# Altium schematic sheet Sheet2.SchDoc(Sheet2)
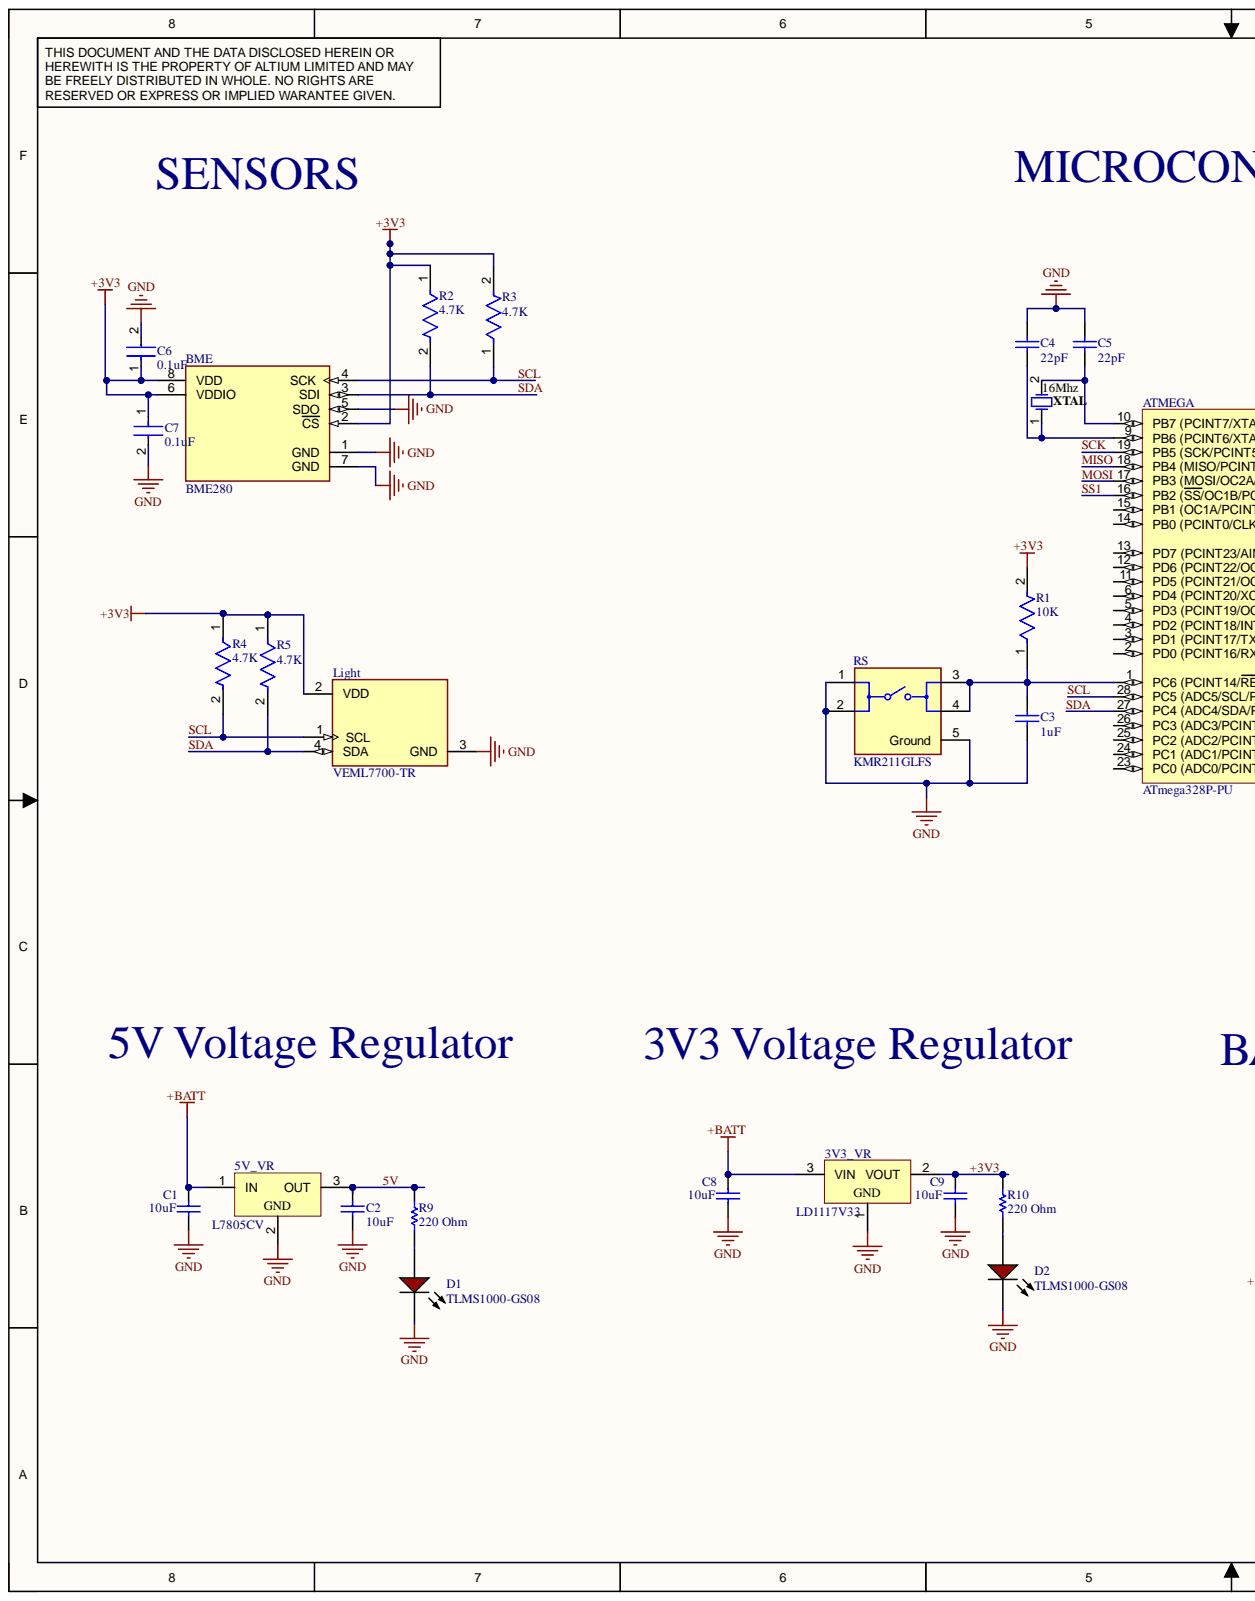
[diagram p0: Sheet2.SchDoc, left]
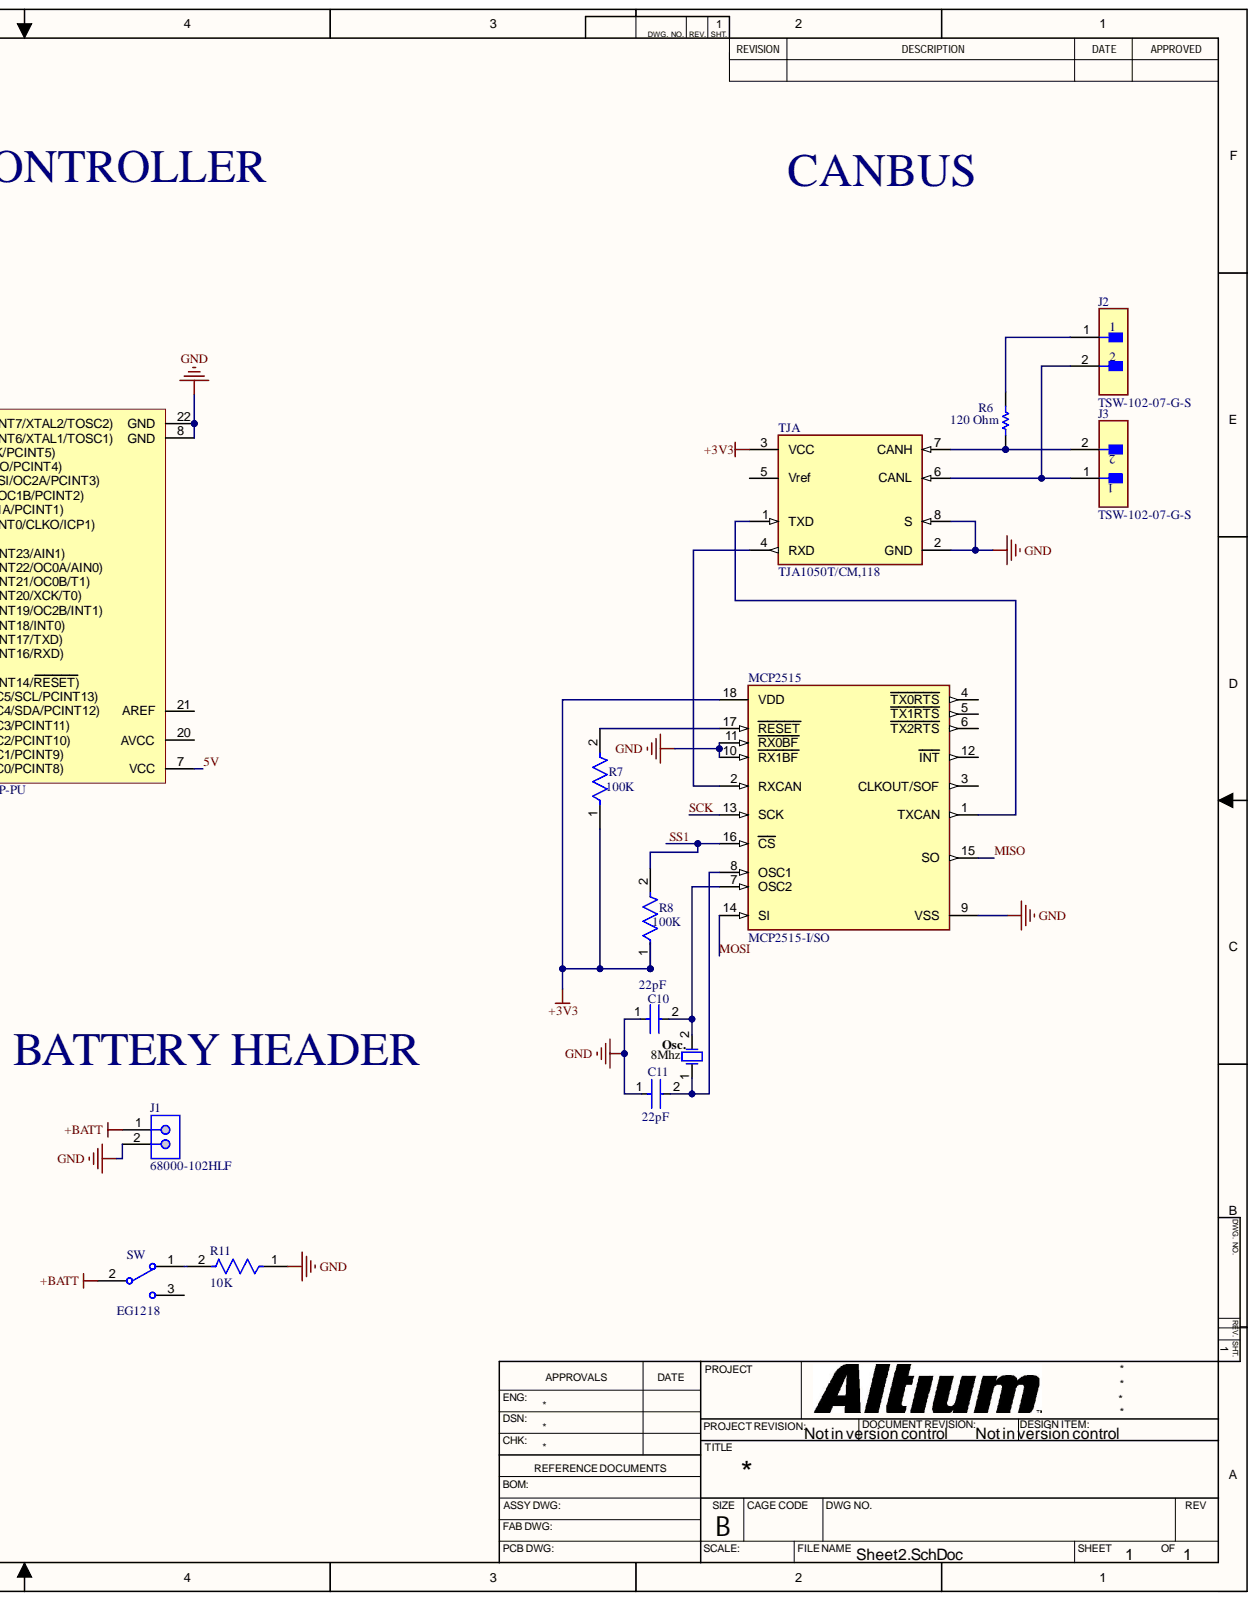
[diagram p1: Sheet2.SchDoc, right]

## Parts
3V3_VR  LD1117V33  [TO220]
5V_VR  L7805CV  [TO220]
ATMEGA  ATmega328P-PU  [28P3]
BME  BME280  [FP-BME280-MFG]
C1  10uF  [CAPC2013X135X45NL10T25]
C2  10uF  [CAPC2013X135X45NL10T25]
C3  1uF  [CAPC1608X90X35ML10T25]
C4  22pF  [CAPC1508X91X25NL25T13]
C5  22pF  [CAPC1508X91X25NL25T13]
C6  0.1uF  [FP-C0805C-DN-MFG]
C7  0.1uF  [FP-C0805C-DN-MFG]
C8  10uF  [CAPC2013X135X45NL10T25]
C9  10uF  [CAPC2013X135X45NL10T25]
C10  22pF  [FP-C0805C-DN-MFG]
C11  22pF  [FP-C0805C-DN-MFG]
D1  TLMS1000-GS08  [VISH-TLMS1000_V]
D2  TLMS1000-GS08  [VISH-TLMS1000_V]
J1  68000-102HLF  [AMPH-68000-102HLF_V]
J2  TSW-102-07-G-S  [FP-TSW-102-07-G-S-MFG]
J3  TSW-102-07-G-S  [FP-TSW-102-07-G-S-MFG]
Light  VEML7700-TR  [FP-6_550-5300_02-4-MFG]
MCP2515  MCP2515-I/SO  [FP-C04-051-MFG]
Osc.  8Mhz  [FOX-FOXSLF080-20]
R1  10K  [FP-CPF0603-MFG]
R2  4.7K  [FP-RT0603-MFG]
R3  4.7K  [FP-RT0603-MFG]
R4  4.7K  [FP-RT0603-MFG]
R5  4.7K  [FP-RT0603-MFG]
R6  120 Ohm  [RESC1608X55X25NL10T15]
R7  100K  [FP-CPF0603-MFG]
R8  100K  [FP-CPF0603-MFG]
R9  220 Ohm  [RESC1608X55X25ML10T15]
R10  220 Ohm  [RESC1608X55X25ML10T15]
R11  10K  [FP-CPF0603-MFG]
RS  KMR211GLFS  [FP-KMR211GLFS-MFG]
SW  EG1218  [ESWI-EG1218_V]
TJA  TJA1050T/CM,118  [FP-SOT96-1-IPC_A]
XTAL  16Mhz  [FOX-FOXSLF160-20]

## Nets
+BATT: 3V3_VR.3 5V_VR.1 C1.1 C8.1 J1.1 SW.2
GND: 3V3_VR.1 5V_VR.2 ATMEGA.8(GND) ATMEGA.22(GND) BME.1(GND) BME.5(SDO) BME.7(GND) C1.2 C2.2 C3.1 C4.2 C5.2 C6.2 C7.2 C8.2 C9.2 C10.1 C11.1 D1.2 D2.2 J1.2 Light.3(GND) MCP2515.9(VSS) MCP2515.10(RX1BF) MCP2515.11(RX0BF) R11.1 RS.1 RS.2 RS.5(Ground) TJA.2(GND) TJA.8(S)
5V: 5V_VR.3 ATMEGA.7(VCC) C2.1 R9.2
+3V3: 3V3_VR.2 BME.2(CS) BME.6(VDDIO) BME.8(VDD) C6.1 C7.1 C9.1 Light.2(VDD) MCP2515.18(VDD) R1.2 R2.1 R3.2 R4.1 R5.1 R7.1 R8.1 R10.2 TJA.3(VCC)
MISO: ATMEGA.18(PB4_(MISO/PCINT4)) MCP2515.15(SO)
MOSI: ATMEGA.17(PB3_(MOSI/OC2A/PCINT3)) MCP2515.14(SI)
SCK: ATMEGA.19(PB5_(SCK/PCINT5)) MCP2515.13(SCK)
SCL: ATMEGA.28(PC5_(ADC5/SCL/PCINT13)) BME.4(SCK) Light.1(SCL) R3.1 R4.2
SDA: ATMEGA.27(PC4_(ADC4/SDA/PCINT12)) BME.3(SDI) Light.4(SDA) R2.2 R5.2
SS1: ATMEGA.16(PB2_(SS/OC1B/PCINT2)) MCP2515.16(CS) R8.2
NetATMEGA_1: ATMEGA.1(PC6_(PCINT14/RESET)) C3.2 R1.1 RS.3 RS.4
NetATMEGA_9: ATMEGA.9(PB6_(PCINT6/XTAL1/TOSC1)) C4.1 XTAL.1
NetATMEGA_10: ATMEGA.10(PB7_(PCINT7/XTAL2/TOSC2)) C5.1 XTAL.2
NetC10_2: C10.2 MCP2515.7(OSC2) Osc..2
NetC11_2: C11.2 MCP2515.8(OSC1) Osc..1
NetD1_1: D1.1 R9.1
NetD2_1: D2.1 R10.1
NetJ2_1: J2.1 R6.2
NetJ2_2: J2.2 J3.1 TJA.6(CANL)
NetJ3_2: J3.2 R6.1 TJA.7(CANH)
NetMCP2515_1: MCP2515.1(TXCAN) TJA.1(TXD)
NetMCP2515_2: MCP2515.2(RXCAN) TJA.4(RXD)
NetMCP2515_17: MCP2515.17(RESET) R7.2
NetR11_2: R11.2 SW.1

Not connected: ATMEGA 2(PD0_(PCINT16/RXD)) 3(PD1_(PCINT17/TXD)) 4(PD2_(PCINT18/INT0)) 5(PD3_(PCINT19/OC2B/INT1)) 6(PD4_(PCINT20/XCK/T0)) 11(PD5_(PCINT21/OC0B/T1)) 12(PD6_(PCINT22/OC0A/AIN0)) 13(PD7_(PCINT23/AIN1)) 14(PB0_(PCINT0/CLKO/ICP1)) 15(PB1_(OC1A/PCINT1)) 20(AVCC) 21(AREF) 23(PC0_(ADC0/PCINT8)) 24(PC1_(ADC1/PCINT9)) 25(PC2_(ADC2/PCINT10)) 26(PC3_(ADC3/PCINT11)); MCP2515 3(CLKOUT/SOF) 4(TX0RTS) 5(TX1RTS) 6(TX2RTS) 12(INT); SW 3; TJA 5(Vref)

## Library data of the parts
3V3_VR = CMP-0244-00470-1: Fixed Low Drop Positive Voltage Regulator, 3.3V, 3-Pin TO-220; Component Kind: Standard; ComponentLink1Description: Manufacturer URL; ComponentLink1URL: http://www.st.com; ComponentLink2Description: Datasheet; ComponentLink2URL: http://www.st.com/internet/com/TECHNICAL_RESOURCES/TECHNICAL_LITERATURE/DATASHEET/CD00000544.pdf; ComponentLink3Description: Package Specification; ComponentLink3URL: http://www.st.com/internet/com/TECHNICAL_RESOURCES/TECHNICAL_LITERATURE/PACKAGE_INFORMATION/CD00004649.pdf; DatasheetVersion: 1/11/2011; Library Name: Altium Content Vault; PackageDescription: TO, Thru-Hole, Vertical, Heatsink Mounted; 3 In-Line Leads; Pitch 2.54 mm; PackageReference: TO220; PackageVersion: ver3.0
5V_VR = CMP-0244-00483-1: Positive Voltage Regulator, 5V, 3-Pin TO-220; Component Kind: Standard; ComponentLink1Description: Manufacturer URL; ComponentLink1URL: http://www.st.com; ComponentLink2Description: Datasheet; ComponentLink2URL: http://www.st.com/internet/com/TECHNICAL_RESOURCES/TECHNICAL_LITERATURE/DATASHEET/CD00000444.pdf; ComponentLink3Description: Package Specification; ComponentLink3URL: http://www.st.com/internet/com/TECHNICAL_RESOURCES/TECHNICAL_LITERATURE/PACKAGE_INFORMATION/CD00004649.pdf; DatasheetVersion: 16/11/2011; Library Name: Altium Content Vault; PackageDescription: TO, Thru-Hole, Vertical, Heatsink Mounted; 3 In-Line Leads; Pitch 2.54 mm; PackageReference: TO220; PackageVersion: ver3.0
ATMEGA = CMP-0095-00013-2: 8-bit AVR Microcontroller, 32KB Flash, 1KB EEPROM, 2KB SRAM, 28-pin PDIP, Industrial Grade (-40°C to 85°C); Component Kind: Standard; ComponentLink1Description: Manufacturer URL; ComponentLink1URL: http://www.atmel.com/; ComponentLink2Description: ATmega48A/48PA/88A/88PA/168A/168PA/328/328P Datasheet; ComponentLink2URL: http://www.atmel.com/dyn/resources/prod_documents/8271.pdf; ComponentLink3Description: ATmega48A/48PA/88A/88PA/168A/168PA/328/328P Summary; ComponentLink3URL: http://www.atmel.com/dyn/resources/prod_documents/8271S.pdf; DatasheetVersion: revD, May-2011; Library Name: Altium Content Vault; PackageDescription: 28-lead (0.300"/7.62mm Wide) Plastic Dual Inline Package (PDIP); PackageReference: 28P3; PackageVersion: revB, Sep-2001; RevisionNote: DatasheetVersion updated. URLs added.
BME = CMP-2000-05073-3: SENSOR PRESSURE HUMIDITY TEMP; Accuracy: 3%; Output Type: SPI; Response Time: 1s; Sensor Type: Temperature; Case/Package: LGA; Component Kind: Standard; Lead Free: Lead Free; Library Name: Altium Content Vault; Max Operating Temperature: 85°C; Min Operating Temperature: -40°C; Mount: Surface Mount; Number of Pins: 8; Packaging: Cut Tape
C1 C2 C8 C9 = CMP-2007-03463-1: Manufacturer: Murata; Part Number: GRM21BR61A106KE19K; Component Kind: Standard; Library Name: Altium Content Vault
C3 = CMP-2000-05447-1: Chip Capacitor, 1 uF, +/- 10%, 50 V, -55 to 85 degC, 0603 (1608 Metric), RoHS, Tape and Reel; Manufacturer: Taiyo Yuden; Capacitance: 1µF; Catalog Drawings: xMKSeries_0603_0.8; Online Catalog: MSeries; Other Names: 587-2400-6; Temperature Coefficient: X5R; Voltage - Rated: 50V; Applications: GeneralPurpose; Category: Capacitors; Component Kind: Standard; Datasheet URL: https://datasheet.ciiva.com/15922/336umk107bj105ka-t-15922611.pdf; Datasheet Version: Mar-13; Family: CeramicCapacitors; Features: -; Height - Seated (Max): -; Lead Spacing: -; Lead Style: -; Library Name: Altium Content Vault; Manufacturer URL: http://www.t-yuden.com/; Mounting Type: SurfaceMount,MLCC; Operating Temperature: -55degC~85degC; Package / Case: 0603(1608Metric); Package Description: 2-Pin Surface Mount Device, Body 1.6 x 0.8 mm; Package Reference: 603; Packaging: Digi-Reel; Ratings: -; Series: M; Size / Dimension: 0.063"Lx0.031"W(1.60mmx0.80mm); Standard Package: 1; Thickness (Max): 0.035"(0.90mm); Tolerance: ±10%
C4 C5 = CMP-2006-04643-1: Manufacturer: AVX Interconnect / Elco; Part Number: 06031U220FAT2A; Component Kind: Standard; Library Name: Altium Content Vault
C6 C7 = CMP-1036-04428-2: CAP CER 0.1UF 100V X7R 0805; Manufacturer: KEMET; Manufacturer Part Number: C0805C104K1RACTU; Capacitance: 0.10 μF; Material: Ceramic; Temperature Coefficient: 30ppm/°C; Tolerance: 0.1; Value: 0.10 μF; Automotive: No; Category: Cap; Component Kind: Standard; ComponentLink2Description: Datasheet; ComponentLink2URL: https://content.kemet.com/datasheets/KEM_C1003_C0G_SMD.pdf; Device Class L1: Passive Components; Device Class L2: Capacitors; Device Class L3: Ceramic Capacitors; Digikey Description: CAP CER 0.1UF 100V X7R 0805; Height: 0.88mm; Ipc Land Pattern Name: CAPC200125X78; Lead Free: Yes; Library Name: Altium Content Vault; Mouser Description: Capacitor 100 nF 100 VDC 0805; Octopart: https://octopart.com/c0805c104k1ractu-kemet-103953; Package: C0805C-DN; RoHS: TRUE; Temperature: -55°C to +125°C; Temperature Characteristic: X7R; Temperature Range High: +125°C; Temperature Range Low: -55°C; Voltage Rating: 100 V
C10 C11 = CMP-2007-00466-2: CAP CER 22PF 50V 10% NP0 0805; Manufacturer: KEMET; Manufacturer Part Number: C0805C220K5GACTU; Material: Ceramic; Temperature Characteristic: C0G; Temperature Coefficient: 30ppm/°C; Tolerance: 0.1; Automotive: No; Capacitance: 22 pF; Category: Cap; Component Kind: Standard; ComponentLink2Description: Datasheet; ComponentLink2URL: https://content.kemet.com/datasheets/KEM_C1003_C0G_SMD.pdf; Device Class L1: Passive Components; Device Class L2: Capacitors; Device Class L3: Ceramic Capacitors; Digikey Description: CAP CER 22PF 50V 10% NP0 0805; Height: 0.88mm; Ipc Land Pattern Name: CAPC200125X78; Lead Free: Yes; Library Name: Altium Content Vault; Mouser Description: Multilayer Ceramic Capacitors MLCC - SMD/SMT 50volts 22pF C0G 10%; Octopart: https://octopart.com/c0805c220k5gactu-kemet-9138623; Package: C0805C-DN; RoHS: TRUE; Temperature: -55°C to +125°C; Temperature Range High: +125°C; Temperature Range Low: -55°C; Value: 22 pF; Voltage Rating: 50 V
D1 D2 = CMP-2000-07254-1: Low Current LED, 40 mW, 1.8 V, -40 to 100 degC, 2-Pin SMD (0603), RoHS, Tape and Reel; Manufacturer: Vishay; Automotive Qualification Standard: AEC-Q100; Bulb Size: 1.6mmx0.8mm; Forward Current If: 2mA; Forward Voltage: 1.8V; LED Colour: Red; Lens Shape: Rectangular; Luminous Intensity: 4mcd; SVHC: ToBeAdvised; Viewing Angle: 80°; Wavelength Typ: 636nm; Component Kind: Standard; Datasheet URL: https://datasheet.ciiva.com/5798/1698881-5798337.pdf; Datasheet Version: Rev. 1.7, 02/2011; LED Mounting: SMD; Library Name: Altium Content Vault; Manufacturer URL: http://www.vishay.com/; Mounting Technology: SurfaceMount; MSL: MSL2-1year; Package Description: 2-Pin Surface Mount Device, Body 1.6 x 0.8 mm; Package Reference: TLMS1000; Packaging: CutTape; Product Range: -
J1 = CMP-2000-05666-1: Male Header, Pitch 2.54 mm, 1 x 2 Position, Height 8.38 mm, Tail Length 2.41 mm, RoHS, Bulk; Brand: FCI; Current Rating: 3A; Insulation Resistance: 5000MOhms; Mating Post Length: 5.84mm; Mounting Angle: Vertical; Number of Positions: 2; Pitch: 2.54mm; Voltage Rating: 1.5kV; Component Kind: Standard; Contact Gender: Pin(Male); Contact Material: PhosphorBronze; Contact Plating: Gold/GTX; Datasheet URL: https://datasheet.ciiva.com/5149/68000-274228-5149165.pdf; Datasheet Version: Rev. F, 04/2006; Factory Pack Quantity: 100; Flammability Rating: UL94V-0; Housing Material: Thermoplastic; Library Name: Altium Content Vault; Manufacturer: FCI; Manufacturer URL: http://www.amphenol.com/; Mounting Style: ThroughHole; Mounting Technology: ThroughHole; Number of Positions / Contacts: 2; Number of Rows: 1; Operating Temperature Range: -65Cto+125C; Package Description: Male Header, Pitch 2.54 mm, 1 x 2 Position, Height 8.38 mm, Tail Length 2.41 mm; Package Reference: 68000-102HLF; Packaging: Bulk; Product Category: Headers&WireHousings; Product Type: Headers; RoHS: Details; Series: 68000; Termination Post Length: 2.41mm; Termination Style: ThroughHole; Type: Unshrouded
J2 J3 = CMP-02766-000084-1: CONN HEADER VERT 2POS 2.54MM; Manufacturer: Samtec; Conn Gender: Male; Conn Orientation: Straight; Current Rating: 6.3A; Number Of Contacts: 2; Voltage Rating: 550V; Automotive: No; Category: Conn; Component Kind: Standard; ComponentLink2Description: Datasheet; ComponentLink2URL: http://suddendocs.samtec.com/catalog_english/tsw_th.pdf; Contact Material: Gold,Nickel; Device Class L1: Connectors; Device Class L2: Headers and Wire Housings; Device Class L3: unset; Digikey Description: CONN HEADER VERT 2POS 2.54MM; Footprint Url: http://suddendocs.samtec.com/prints/tsw-xxx-xx-x-x-xx-xxx-footprint.pdf; Height: 8.71mm; Lead Free: Yes; Library Name: Altium Content Vault; Manufacturer Part Number: TSW-102-07-G-S; Mouser Description: Conn Unshrouded Header HDR 2 POS 2.54mm Solder ST Thru-Hole Automotive Bulk; Number Of Rows: 1; Octopart: https://octopart.com/tsw-102-07-g-s-samtec-272197; Package: TSW-102-07-G-S; Pitch: 2.54mm; RoHS: TRUE; Temperature: -55°C to +125°C; Temperature Range High: +125°C; Temperature Range Low: -55°C
Light = CMP-02530-000005-1: SENSOR OPT 545NM AMBIENT 4SMD; Manufacturer: Vishay; Max Junction Temp: +100°C; Max Supply Voltage: 3.6V; Min Supply Voltage: 2.5V; Operating Supply Current: 2-45uA; Sensor Output: I2C; Ambient Temperature Range High: +85°C; Ambient Temperature Range Low: -25°C; Automotive: No; Category: IC; Component Kind: Standard; ComponentLink2Description: Datasheet; ComponentLink2URL: http://www.vishay.com/docs/84286/veml7700.pdf; Device Class L1: Sensors; Device Class L2: Optical Sensors; Device Class L3: unset; Digikey Description: SENSOR OPT 545NM AMBIENT 4SMD; Height: 3.15mm; Lead Free: Yes; Library Name: Altium Content Vault; Manufacturer Part Number: VEML7700-TR; Octopart: https://octopart.com/veml7700-tr-vishay-70519713; Package: 6.550-5300.02-4; RoHS: TRUE; Temperature: to +100°C; Temperature Range High: +100°C
MCP2515 = CMP-0186-00133-3: IC CAN CONTROLLER W/SPI 18SOIC; Manufacturer: Microchip; Interface: SPI,CAN; Max Supply Voltage: 5.5V; Min Supply Voltage: 2.7V; Nominal Supply Current: 10mA; Standoff Height: 0.1mm; Ambient Temperature Range High: +125°C; Ambient Temperature Range Low: -65°C; Automotive: No; Category: IC; Component Kind: Standard; ComponentLink2Description: Datasheet; ComponentLink2URL: http://ww1.microchip.com/downloads/en/DeviceDoc/MCP2515-Stand-Alone-CAN-Controller-with-SPI-20001801J.pdf; Device Class L1: Integrated Circuits (ICs); Device Class L2: Interface ICs; Device Class L3: Controllers; Digikey Description: IC CAN CONTROLLER W/SPI 18SOIC; Footprint Url: http://ww1.microchip.com/downloads/en/DeviceDoc/18L_SOIC_Wide_7.50_SO_C04-0051D.pdf; Function: Controller; Height: 2.65mm; Ipc Land Pattern Name: SOIC127P1030X265-18; Lead Free: Yes; Library Name: Altium Content Vault; Manufacturer Part Number: MCP2515-I/SO; Mouser Description: CAN Interface IC W/ SPI Interface; Octopart: https://octopart.com/mcp2515-i%2Fso-microchip-430187; Package: C04-051; RoHS: TRUE; Temperature: -40°C to +85°C; Temperature Range High: +85°C; Temperature Range Low: -40°C
Osc. = CMP-1749-00002-1: Resistance Weld Thru-Hole Crystal, 8 MHz, -20 to 70 degC, 2-Pin THD, RoHS, Bulk; Case\Package: TO-263-3; Dropout Voltage: 2 V; Max Input Voltage: 35 V; Max Ouput Current: 1 A; Min Input Voltage: 7 V; Nominal Output Voltage: 5 V; Outputs: 1; Component Kind: Standard; ComponentLink1Description: Manufacturer URL; ComponentLink1URL: http://www.foxonline.com/; ComponentLink2Description: Datasheet; ComponentLink2URL: http://download.siliconexpert.com/pdfs/2015/4/5/6/5/7/200/fox_/manual/35607121449963728hc49slf.pdf; DatasheetVersion: Mar-15; Height: 30.02 mm; Length: 10.24 mm; Library Name: Altium Content Vault; Max Operating Temperature: 125 degC; Min Operating Temperature: 0 degC; Mount: Surface Mount; Output Current: 1 A; Output Voltage: 5 V; Package Quantity: 50; PackageDescription: 2-Pin Through Hole Device, Body 11.35 x 4.65 mm; PackageReference: FOXSLF080-20; Packaging: Rail/Tube; Pins: 3; Radiation Hardening: No; REACH SVHC: No SVHC; RoHSCompliant: true; Width: 9.35 mm
R1 R11 = CMP-03211-008304-1: RES SMD 10KΩ 1% 1/16W 0603; Manufacturer: TE Connectivity; Manufacturer Part Number: CPF0603F10KC1; Power Rating: 0.063W; Temperature Coefficient: 50ppm/°C; Voltage Rating: 50V; Automotive: No; Category: Res; Component Kind: Standard; ComponentLink2Description: Datasheet; ComponentLink2URL: https://www.te.com/commerce/DocumentDelivery/DDEController?Action=showdoc&DocId=Data+Sheet%7F1773200%7FM%7Fpdf%7FEnglish%7FENG_DS_1773200_M.pdf%7F3-1676481-2; Composition: Thin Film; Device Class L1: Passive Components; Device Class L2: Resistors; Device Class L3: Chip SMD Resistors; Height: 0.55mm; Ipc Land Pattern Name: RESC15580X45; Lead Free: Yes; Library Name: Altium Content Vault; Octopart: https://octopart.com/cpf0603f10kc1-te+connectivity-42013076; octopart_url: https://octopart.com/cpf0603f10kc1-te+connectivity-42013076; Package: CPF0603; packaging: 1k Reel; Resistance: 10KΩ; RoHS: TRUE; Series: CPF; Temperature: -55°C to +155°C; Temperature Range High: +155°C; Temperature Range Low: -55°C; Tolerance: 1%; Value: 10KΩ
R2-R5 = CMP-2000-04545-2: RES SMD 4.7K OHM 0.1% 1/10W 0603; Manufacturer: Yageo; Manufacturer Part Number: RT0603BRE074K7L; Power Rating: 0.1W; Resistance: 4.7KΩ; Temperature Coefficient: 50 ppm/°C; Tolerance: 0.001; Value: 4.7KΩ; Voltage Rating: 75V; Automotive: No; Category: Res; Component Kind: Standard; ComponentLink2Description: Datasheet; ComponentLink2URL: http://www.yageo.com/documents/recent/PYu-RT_1-to-0.01_RoHS_L_9.pdf; Composition: Thin Film; Device Class L1: Passive Components; Device Class L2: Resistors; Device Class L3: Chip SMD Resistors; Digikey Description: RES SMD 4.7K OHM 0.1% 1/10W 0603; Footprint Url: http://www.yageo.com/exep/pages/download/literatures/PYu-R_Mount_10.pdf; Height: 0.55mm; Ipc Land Pattern Name: RESC16080X45; Lead Free: Yes; Library Name: Altium Content Vault; Octopart: https://octopart.com/rt0603bre074k7l-yageo-1207135; Package: RT0603; RoHS: TRUE; Series: RT; Temperature: -55°C to +155°C; Temperature Range High: +155°C; Temperature Range Low: -55°C
R6 = CMP-1659-00034-1: Chip Resistor, 120 Ohm, +/- 1%, 100 mW, -55 to 155 degC, 0603 (1608 Metric), RoHS, Tape and Reel; Case\Package: 0603; Forward Current: 20 mA; Forward Voltage: 2.1 V; Illumination Colour: Orange; Lens Style: Diffused; Luminous Intensity: 80 mcd; Nominal Wavelength: 606 nm; Power Rating: 90 mW; Viewing Angle: 160 degrees; Case Code (Imperial): 0603; Case Code (Metric): 1608; Colour: Orange; Component Kind: Standard; ComponentLink1Description: Manufacturer URL; ComponentLink1URL: http://www.yageo.com/; ComponentLink2Description: Datasheet; ComponentLink2URL: http://www.yageo.com/documents/recent/PYu-RC_Group_51_RoHS_L_04.pdf; DatasheetVersion: Rev. 4, 02/2016; Height: 0.6 mm; Length: 1.6 mm; Library Name: Altium Content Vault; Max Operating Temperature: 100 degC; Min Operating Temperature: -40 degC; Mount: Surface Mount; Nominal Vf: 2.1 V; Package Quantity: 3000; PackageDescription: 2-Pin Surface Mount Device, Body 1.6 x 0.8 mm; PackageReference: 0603; Packaging: Tape and Reel; Pins: 2; Radiation Hardening: No; REACH SVHC: Unknown; RoHSCompliant: true; Width: 0.8 mm
R7 R8 = CMP-03211-008043-1: RES SMD 100KΩ 0.1% 1/16W 0603; Manufacturer: TE Connectivity; Manufacturer Part Number: CPF0603B100KE1; Power Rating: 0.063W; Temperature Coefficient: 25ppm/°C; Voltage Rating: 50V; Automotive: No; Category: Res; Component Kind: Standard; ComponentLink2Description: Datasheet; ComponentLink2URL: https://www.te.com/commerce/DocumentDelivery/DDEController?Action=showdoc&DocId=Data+Sheet%7F1773200%7FM%7Fpdf%7FEnglish%7FENG_DS_1773200_M.pdf%7F3-1676481-2; Composition: Thin Film; Device Class L1: Passive Components; Device Class L2: Resistors; Device Class L3: Chip SMD Resistors; Height: 0.55mm; Ipc Land Pattern Name: RESC15580X45; Lead Free: Yes; Library Name: Altium Content Vault; Octopart: https://octopart.com/cpf0603b100ke1-te+connectivity-9539443; octopart_url: https://octopart.com/cpf0603b100ke1-te+connectivity-9539443; Package: CPF0603; packaging: 1k Reel; Resistance: 100KΩ; RoHS: TRUE; Series: CPF; Temperature: -55°C to +155°C; Temperature Range High: +155°C; Temperature Range Low: -55°C; Tolerance: 0.1%; Value: 100KΩ
R9 R10 = CMP-1659-00018-2: General Purpose Chip Resistors, 220 Ohm, +/- 1%, -55 to 155 degC, 0603 (1608 Metric), RoHS, Tape and Reel; Component Kind: Standard; ComponentLink1Description: Manufacturer URL; ComponentLink1URL: http://www.yageo.com/; ComponentLink2Description: Datasheet; ComponentLink2URL: https://datasheet.ciiva.com/11808/0900766b80f96399-11808136.pdf; DatasheetVersion: Rev. 4, 04/2009; Library Name: Altium Content Vault; PackageDescription: 2-Pin Surface Mount Device, Body 1.6 x 0.8 mm; PackageReference: 0603; RoHSCompliant: false
RS = CMP-10040-000023-1: SWITCH TACTILE SPST-NO 0.05A 32V; Actuator Length: 0.5mm; Actuator Type: Standard; Contact Current Rating: 50mA; Illumination Type: Non-Illuminated; Mechanical Life: 200000Cycles; Operating Force: 1.2N; Power Rating: 1W; Switch Function: Off-Mom; Switch Travel: 0.2mm; Throw Configuration: SPST; Component Kind: Standard; Contact Material: Silver; Contact Plating: Silver; Current Rating: 50mA; Depth: 4.6mm; Lead Free: Lead Free; Length: 2.8mm; Library Name: Altium Content Vault; Max Current Rating: 50mA; Max Operating Temperature: 85°C; Max Voltage Rating (DC): 32V; Min Operating Temperature: -40°C; Mount: Surface Mount; Orientation: Straight; Package Quantity: 1; Packaging: Tape and Reel; Radiation Hardening: No; REACH SVHC: No SVHC; Termination: Gull Wing; Voltage Rating (DC): 32V; Width: 2.8mm
SW = CMP-1696-00002-1: Slide Switch, 500 V, -20 to 70 degC, 3-Pin THD, RoHS; Case\Package: SOT-23-5; Dropout Voltage: 300 mV; Max Input Voltage: 8 V; Max Ouput Current: 150 mA; Max Power Dissipation: 250 mW; Min Input Voltage: 4.3 V; Nominal Output Voltage: 3.3 V; Outputs: 1; Component Kind: Standard; ComponentLink1Description: Manufacturer URL; ComponentLink1URL: http://www.e-switch.ch/en/; ComponentLink2Description: Datasheet; ComponentLink2URL: https://www.e-switch.com/system/asset/product_line/data_sheet/119/EG.pdf; DatasheetVersion: Oct-15; Height: 1.3 mm; Length: 3 mm; Library Name: Altium Content Vault; Max Operating Temperature: 85 degC; Min Operating Temperature: -40 degC; Mount: Surface Mount; Output Current: 150 mA; Output Voltage: 3.3 V; Package Quantity: 3000; PackageDescription: 3-Pin Through Hole Device, Body 11.6 x 4 mm, Pitch 2.5 mm; PackageReference: EG1218; Packaging: Tape and Reel; Pins: 5; Power Rating: 250 mW; Radiation Hardening: No; REACH SVHC: No SVHC; RoHSCompliant: true; Width: 1.8 mm
TJA = CMP-2000-04923-2: IC TRANSCEIVER HALF 1/1 8SO; Data Rate: 1Mbps; Interface: CAN; Max Supply Current: 10mA; Max Supply Voltage: 5.25V; Min Supply Voltage: 4.75V; Number of Receive Buffers: 1; Receiver Hysteresis: 70mV; Simplex/Duplex: Half Duplex; Case/Package: SO; Component Kind: Standard; Contact Plating: Gold; Lead Free: Lead Free; Library Name: Altium Content Vault; Max Operating Temperature: 150°C; Min Operating Temperature: -40°C; Mount: Surface Mount; Nominal Supply Current: 10mA; Number of Pins: 8; Number of Transceivers: 1; Number of Transmit Buffers: 1; Package Quantity: 2500; Packaging: Tape and Reel; Radiation Hardening: No; REACH SVHC: No SVHC
XTAL = CMP-1749-00003-1: Resistance Weld Thru-Hole Crystal, 16 MHz, -20 to 70 degC, 2-Pin THD, RoHS, Bulk; Case\Package: Axial; Power Rating: 250 mW; Resistance: 221 kohm; Tolerance: 1%; Voltage Rating: 250 V; Component Kind: Standard; ComponentLink1Description: Manufacturer URL; ComponentLink1URL: http://www.foxonline.com/; ComponentLink2Description: Datasheet; ComponentLink2URL: http://www.foxonline.com/pdfs/hc49slf.pdf; DatasheetVersion: Mar-15; Length: 0.248 inch; Library Name: Altium Content Vault; Max Operating Temperature: 155 degC; Min Operating Temperature: -55 degC; Mount: Through Hole; Package Quantity: 200; PackageDescription: 2-Pin Through Hole Device, Body 11.35 x 4.65 mm; PackageReference: FOXSLF160-20; Packaging: Bulk; RoHSCompliant: true
